# Altium schematic sheet Ethernet.SchDoc(Ethernet)
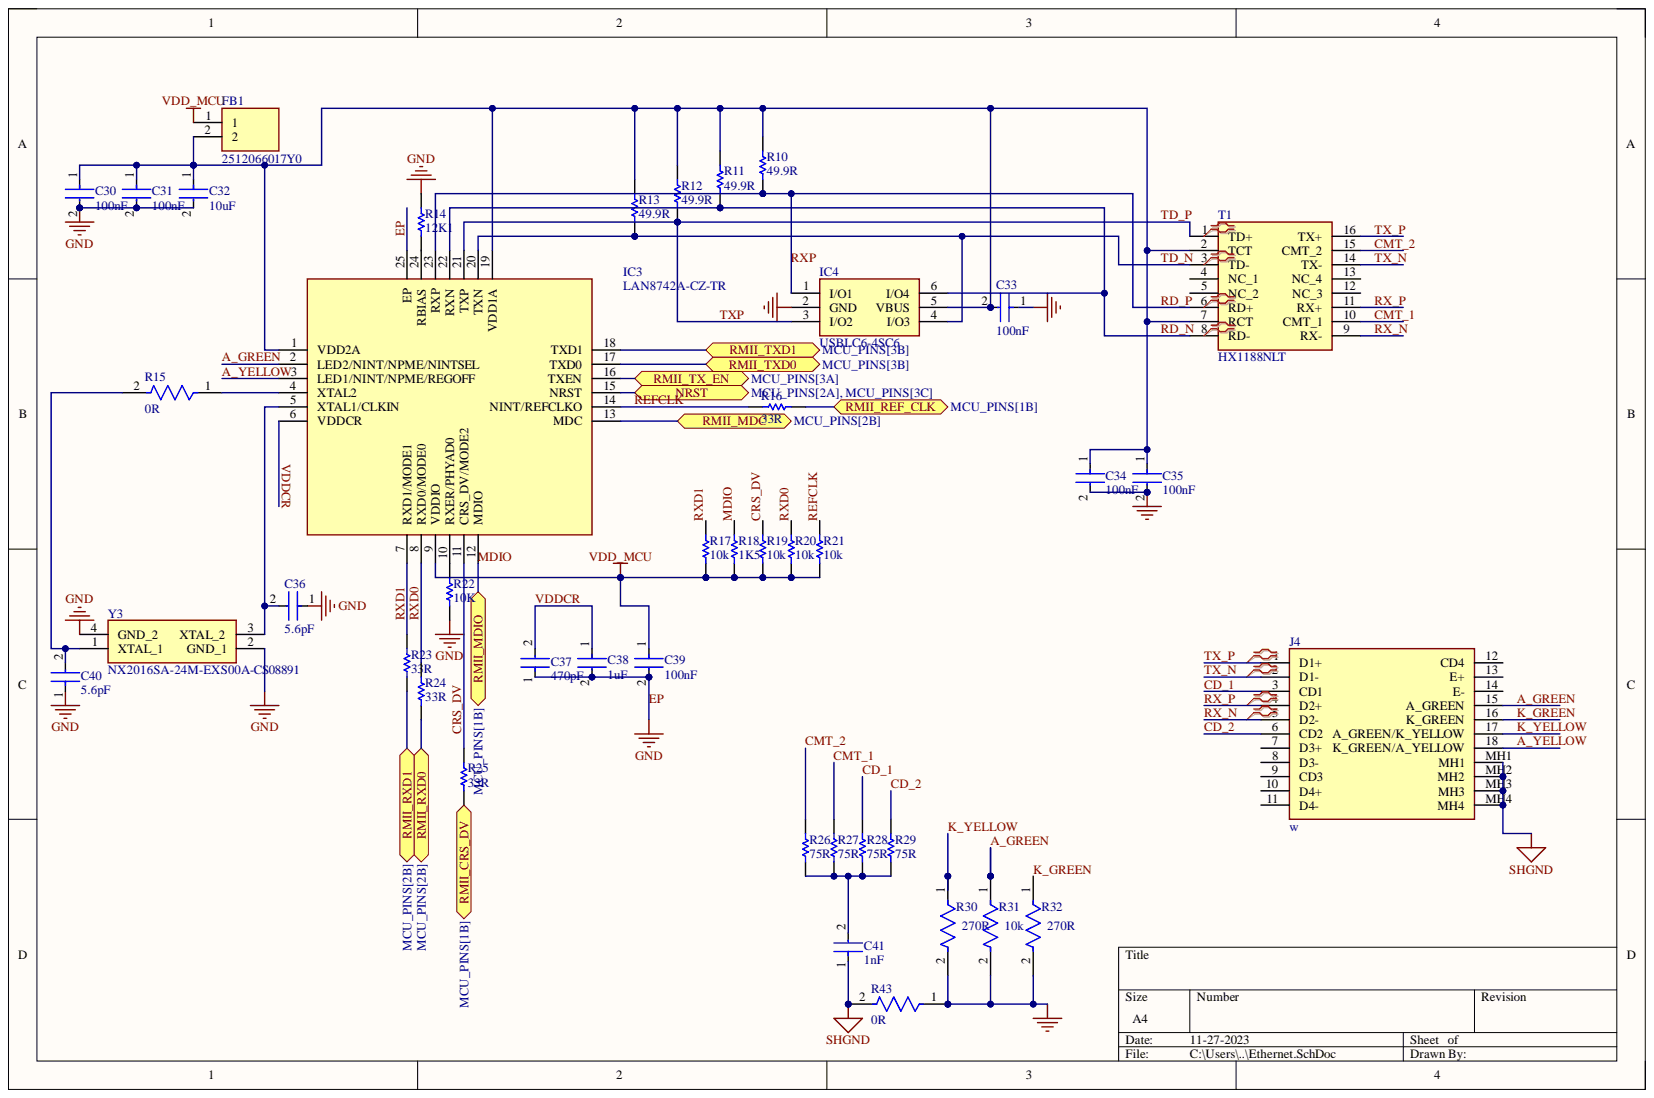
[diagram p0: Ethernet.SchDoc]

## Parts
C30  100nF  [FP-C0603C-CF-MFG]
C31  100nF  [FP-C0603C-CF-MFG]
C32  10uF  [FP-C0603C-CF-MFG]
C33  100nF  [FP-C0603C-CF-MFG]
C34  100nF  [FP-C0603C-CF-MFG]
C35  100nF  [FP-C0603C-CF-MFG]
C36  5.6pF  [FP-C0603C-CF-MFG]
C37  470pF  [FP-C0603C-CF-MFG]
C38  1uF  [FP-C0603C-CF-MFG]
C39  100nF  [FP-C0603C-CF-MFG]
C40  5.6pF  [FP-C0603C-CF-MFG]
C41  1nF  [FP-C0603C-CF-MFG]
FB1  2512066017Y0  [BEADC3211X180N]
IC3  LAN8742A-CZ-TR  [QFN50P400X400X100-25N-D]
IC4  USBLC6-4SC6  [SOT95P280X145-6N]
J4  w  [7499611424]
R10  49.9R  [RESC1005X40X25NL10T10]
R11  49.9R  [RESC1005X40X25NL10T10]
R12  49.9R  [RESC1005X40X25NL10T10]
R13  49.9R  [RESC1005X40X25NL10T10]
R14  12K1  [RESC1005X40X25NL10T10]
R15  0R  [FP-RT0603-MFG]
R16  33R  [RESC1005X40X25NL10T10]
R17  10k  [RESC1005X40X25NL10T10]
R18  1K5  [RESC1005X40X25NL10T10]
R19  10k  [RESC1005X40X25NL10T10]
R20  10k  [RESC1005X40X25NL10T10]
R21  10k  [RESC1005X40X25NL10T10]
R22  10K  [RESC1005X40X25NL10T10]
R23  33R  [RESC1005X40X25NL10T10]
R24  33R  [RESC1005X40X25NL10T10]
R25  33R  [RESC1005X40X25NL10T10]
R26  75R  [RESC1005X40X25NL10T10]
R27  75R  [RESC1005X40X25NL10T10]
R28  75R  [RESC1005X40X25NL10T10]
R29  75R  [RESC1005X40X25NL10T10]
R30  270R  [FP-RT0603-MFG]
R31  10k  [FP-RT0603-MFG]
R32  270R  [FP-RT0603-MFG]
R43  0R  [FP-RT0603-MFG]
T1  HX1188NLT  [HX1188NLT]
Y3  NX2016SA-24M-EXS00A-CS08891  [NX2016SA24MEXS00ACS08891]

## Ports
NRST, RMII_CRS_DV, RMII_MDC, RMII_MDIO, RMII_REF_CLK, RMII_RXD0, RMII_RXD1, RMII_TX_EN, RMII_TXD0, RMII_TXD1

## Nets
SHGND: C41.1 J4.MH1 J4.MH2 J4.MH3 J4.MH4 R43.2
VDD_MCU: C39.1 FB1.1 IC3.9(VDDIO) R17.2 R18.2 R19.2 R20.2 R21.2
A_GREEN: IC3.2(LED2/NINT/NPME/NINTSEL) J4.15(A_GREEN) R31.1
A_YELLOW: IC3.3(LED1/NINT/NPME/REGOFF) J4.18(K_GREEN/A_YELLOW)
CD_1: J4.3(CD1) R28.1
CD_2: J4.6(CD2) R29.1
CMT_1: R27.1 T1.10(CMT_1)
CMT_2: R26.1 T1.15(CMT_2)
CRS_DV: IC3.11(CRS_DV/MODE2) R19.1 R25.1
EP: C30.2 C31.2 C32.2 C33.1 C34.2 C35.2 C36.1 C37.1 C38.2 C39.2 C40.1 IC3.25(EP) IC4.2(GND) R14.1 R22.2 R30.2 R31.2 R32.2 R43.1 Y3.2(GND_1) Y3.4(GND_2)
K_GREEN: J4.16(K_GREEN) R32.1
K_YELLOW: J4.17(A_GREEN/K_YELLOW) R30.1
MDIO: IC3.12(MDIO) R18.1 ; port RMII_MDIO
NRST: IC3.15(NRST) ; port NRST
RD_N: IC3.22(RXN) IC4.6(I/O4) R11.2 T1.8(RD-)
RD_P: IC3.23(RXP) IC4.1(I/O1) R10.2 T1.6(RD+)
REFCLK: IC3.14(NINT/REFCLKO) R16.2 R21.1
RMII_CRS_DV: R25.2 ; port RMII_CRS_DV
RMII_MDC: IC3.13(MDC) ; port RMII_MDC
RMII_REF_CLK: R16.1 ; port RMII_REF_CLK
RMII_RXD0: R24.2 ; port RMII_RXD0
RMII_RXD1: R23.2 ; port RMII_RXD1
RMII_TX_EN: IC3.16(TXEN) ; port RMII_TX_EN
RMII_TXD0: IC3.17(TXD0) ; port RMII_TXD0
RMII_TXD1: IC3.18(TXD1) ; port RMII_TXD1
RX_N: J4.5(D2-) T1.9(RX-)
RX_P: J4.4(D2+) T1.11(RX+)
RXD0: IC3.8(RXD0/MODE0) R20.1 R24.1
RXD1: IC3.7(RXD1/MODE1) R17.1 R23.1
TD_N: IC3.20(TXN) IC4.4(I/O3) R13.2 T1.3(TD-)
TD_P: IC3.21(TXP) IC4.3(I/O2) R12.2 T1.1(TD+)
TX_N: J4.2(D1-) T1.14(TX-)
TX_P: J4.1(D1+) T1.16(TX+)
VDDCR: C37.2 C38.1 IC3.6(VDDCR)
NetC30_1: C30.1 C31.1 C32.1 C33.2 C34.1 C35.1 FB1.2 IC3.1(VDD2A) IC3.19(VDD1A) IC4.5(VBUS) R10.1 R11.1 R12.1 R13.1 T1.2(TCT) T1.7(RCT)
NetC36_2: C36.2 IC3.5(XTAL1/CLKIN) Y3.3(XTAL_2)
NetC40_2: C40.2 R15.2 Y3.1(XTAL_1)
NetC41_2: C41.2 R26.2 R27.2 R28.2 R29.2
NetIC3_4: IC3.4(XTAL2) R15.1
NetIC3_10: IC3.10(RXER/PHYAD0) R22.1
NetIC3_24: IC3.24(RBIAS) R14.2

Not connected: J4 7(D3+) 8(D3-) 9(CD3) 10(D4+) 11(D4-) 12(CD4) 13(E+) 14(E-); T1 4(NC_1) 5(NC_2) 12(NC_3) 13(NC_4)

## Library data of the parts
C30-C41 = CMP-2006-03061-2: CAP CER 0.1UF 50V X7R 0603; Manufacturer: KEMET; Manufacturer Part Number: C0603C104K5RACTU; Automotive Grade: Grade 1; Capacitance: 0.10 μF; Material: Ceramic; Temperature Coefficient: 30ppm/°C; Tolerance: 0.1; Value: 0.10 μF; Automotive: Yes; Category: Cap; Component Kind: Standard; ComponentLink2Description: Datasheet; ComponentLink2URL: https://api.kemet.com/component-edge/download/datasheet/C0603C100J5GACAUTO.pdf; Device Class L1: Passive Components; Device Class L2: Capacitors; Device Class L3: Ceramic Capacitors; Digikey Description: CAP CER 0.1UF 50V X7R 0603; Height: 0.87mm; Lead Free: Yes; Library Name: Altium Content Vault; Octopart: https://octopart.com/c0603c104k5ractu-kemet-139244; Package: C0603C-CF; RoHS: TRUE; Temperature: -55°C to +125°C; Temperature Characteristic: X7R; Temperature Range High: +125°C; Temperature Range Low: -55°C; Voltage Rating: 50 V
FB1 = 2512066017Y0: Ferrite Bead; Manufacturer_Name: Fair-Rite; Arrow Part Number: 2512066017Y0; Arrow Price/Stock: https://www.arrow.com/en/products/2512066017y0/fair-rite-products?region=nac; Component Kind: Standard; Datasheet Link: https://componentsearchengine.com/Datasheets/2/2512066017Y0.pdf; Height: 1.8mm; Manufacturer_Part_Number: 2512066017Y0; Mouser Part Number: 623-2512066017Y0; Mouser Price/Stock: https://www.mouser.com/Search/Refine.aspx?Keyword=623-2512066017Y0
IC3 = LAN8742A-CZ-TR: Integrated Circuit; Manufacturer_Name: Microchip; Arrow Part Number: LAN8742A-CZ-TR; Arrow Price/Stock: https://www.arrow.com/en/products/lan8742a-cz-tr/microchip-technology?region=nac; Component Kind: Standard; Datasheet Link: http://ww1.microchip.com/downloads/en/DeviceDoc/8742a.pdf; Height: 1mm; Manufacturer_Part_Number: LAN8742A-CZ-TR; Mouser Part Number: 579-LAN8742A-CZ-TR; Mouser Price/Stock: https://www.mouser.co.uk/ProductDetail/Microchip-Technology/LAN8742A-CZ-TR?qs=6qKXPk0vzx0Y6uPCt7MXWw%3D%3D
IC4 = USBLC6-4SC6: Integrated Circuit; Manufacturer_Name: STMicroelectronics; Arrow Part Number: USBLC6-4SC6; Arrow Price/Stock: https://www.arrow.com/en/products/usblc6-4sc6/stmicroelectronics?region=nac; Component Kind: Standard; Datasheet Link: http://www.st.com/st-web-ui/static/active/en/resource/technical/document/datasheet/CD00047494.pdf; Height: 1.45mm; Manufacturer_Part_Number: USBLC6-4SC6; Mouser Part Number: 511-USBLC6-4SC6; Mouser Price/Stock: https://www.mouser.co.uk/ProductDetail/STMicroelectronics/USBLC6-4SC6?qs=k9dH%2Fx4GHJCNdehb8zInZg%3D%3D
J4 = 7499611424: Connector; Manufacturer_Name: Wurth Elektronik; Component Kind: Standard; Datasheet Link: https://www.we-online.de/katalog/datasheet/7499611424.pdf; Height: 11.55mm; Manufacturer_Part_Number: 7499611424; Mouser Part Number: 710-7499611424; Mouser Price/Stock: https://www.mouser.co.uk/ProductDetail/Wurth-Elektronik/7499611424?qs=ljCeji4nMDlfhtZnezZNfA%3D%3D
R10-R14 R16-R29 = CMP-2002-05217-1: Manufacturer: Bourns; Part Number: CR0402-FX-4701GLF; Component Kind: Standard; Library Name: Altium Content Vault
R15 R30-R32 R43 = CMP-030-000001-1: RES SMD 10K OHM 1% 1/10W 0603; Manufacturer: Yageo; Manufacturer Part Number: RT0603FRE0710KL; Power Rating: 0.1W; Resistance: 10KO; Temperature Coefficient: 50 ppm/°C; Tolerance: 0.01; Value: 10KO; Voltage Rating: 75V; Automotive: No; Category: Res; Component Kind: Standard; Component Type: Standard; Composition: Thin Film; Design Item ID: CMP-03412-005349-1; Device Class L1: Passive Components; Device Class L2: Resistors; Device Class L3: Chip SMD Resistors; Digikey Description: RES SMD 10K OHM 1% 1/10W 0603; Footprint Url: http://www.yageo.com/exep/pages/download/literatures/PYu-R_Mount_10.pdf; Height: 0.55mm; Ipc Land Pattern Name: RESC16080X45; Lead Free: Yes; Library Name: DH08; Mouser Description: Thin Film Resistors - SMD 10K ohm 1% 1/10W; Octopart: https://octopart.com/rt0603fre0710kl-yageo-40009243; Package: RT0603; Pin Count: 2; RoHS: TRUE; Series: RT; Temperature: -55°C to +155°C; Temperature Range High: +155°C; Temperature Range Low: -55°C; Source: DH07_Optical_Localization_Sensor_V2_Main_1.SCHLIB
T1 = HX1188NLT: Transformer; Manufacturer_Name: Pulse Electronics; Arrow Part Number: HX1188NLT; Arrow Price/Stock: https://www.arrow.com/en/products/hx1188nlt/pulse-electronics-corporation?region=europe; Component Kind: Standard; Datasheet Link: https://datasheet.datasheetarchive.com/originals/distributors/Datasheets-DGA2/221032.pdf; Height: 6.07mm; Manufacturer_Part_Number: HX1188NLT; Mouser Part Number: 673-HX1188NLT; Mouser Price/Stock: https://www.mouser.co.uk/ProductDetail/Pulse-Electronics/HX1188NLT?qs=7h3O1UVlCGOyAkRk4EAe8A%3D%3D
Y3 = NX2016SA-24M-EXS00A-CS08891: Crystal or Oscillator; Manufacturer_Name: NDK; Component Kind: Standard; Datasheet Link: http://www.ndk.com/images/products/catalog/c_NX2016SA_e.pdf; Height: 0.5mm; Manufacturer_Part_Number: NX2016SA-24M-EXS00A-CS08891; Mouser Part Number: 344-NX2016SA24S08891; Mouser Price/Stock: https://www.mouser.co.uk/ProductDetail/NDK/NX2016SA-24M-EXS00A-CS08891?qs=w%2Fv1CP2dgqoU%2FAdFbbSfhw%3D%3D
